# Altium schematic sheet DSP.SchDoc
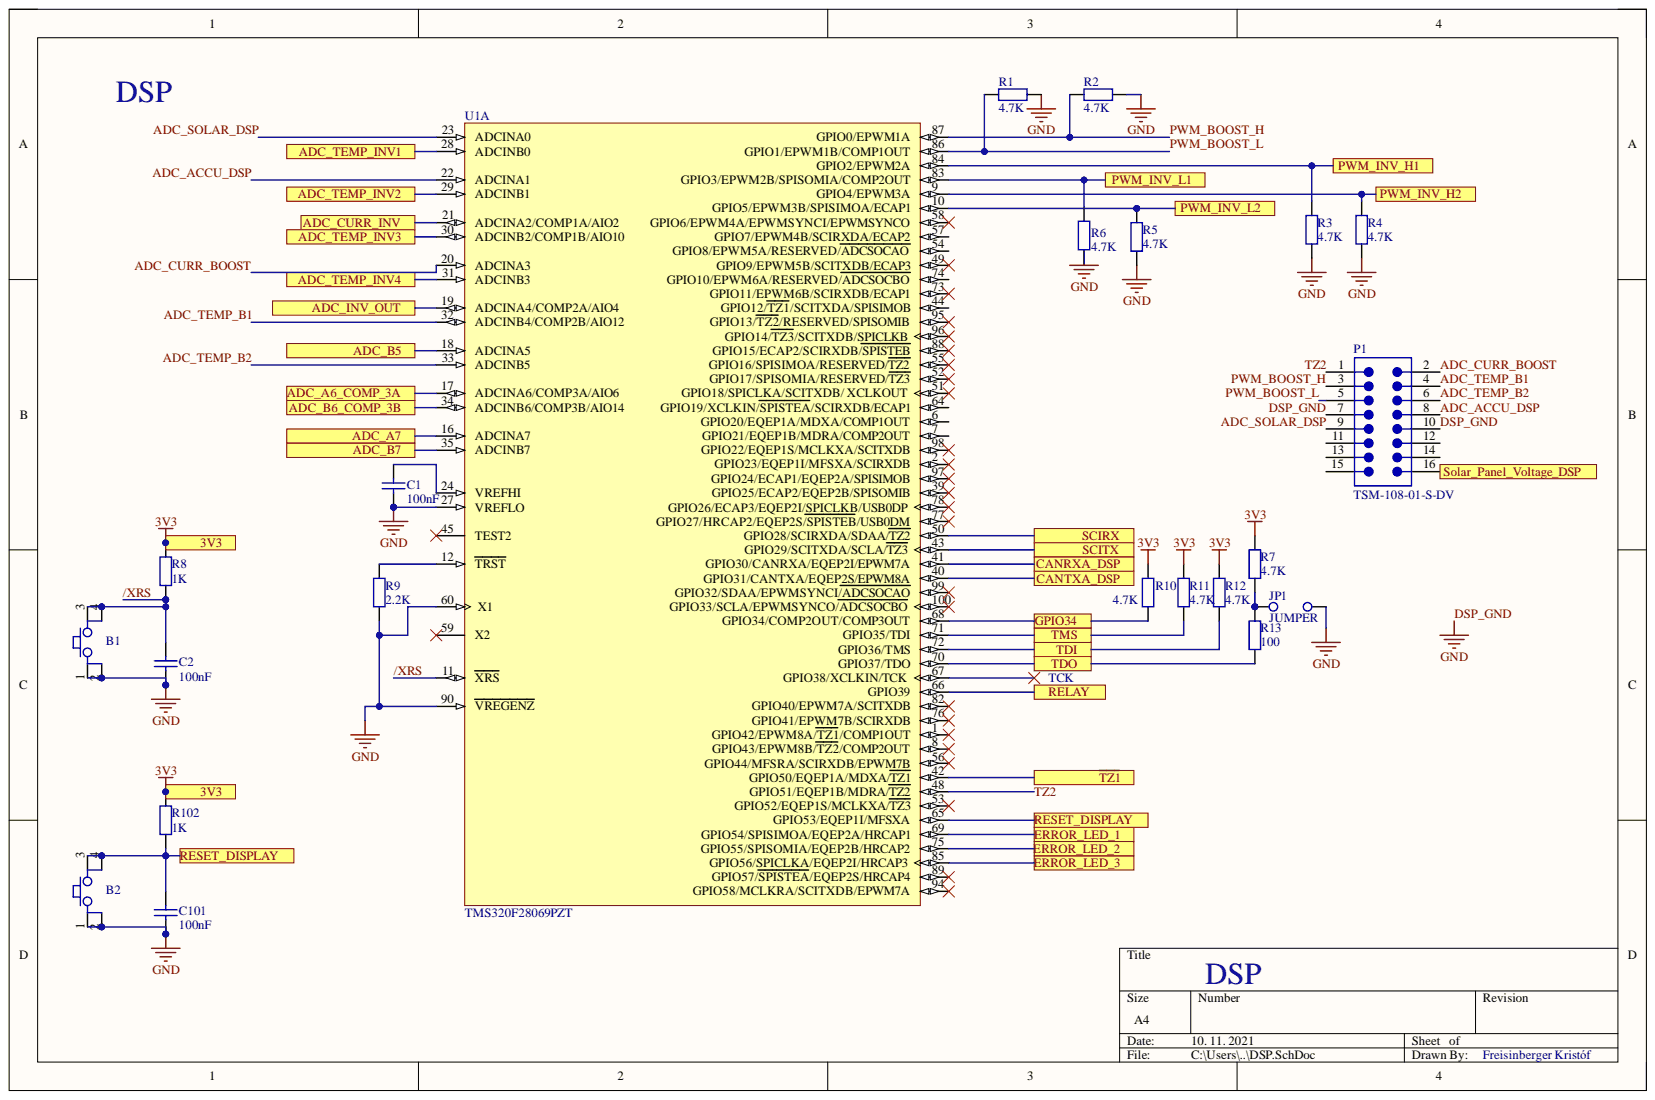
[diagram p0: DSP.SchDoc]

## Parts
B1  Button  [FP_BTN_SMD]  (value not drawn)
B2  Button  [FP_BTN_SMD]  (value not drawn)
C1  100nF  [FP_0805_CAP_085]
C2  100nF  [FP_0805_CAP_085]
C101  100nF  [FP_0805_CAP_085]
JP1  JUMPER  [FP_CONN2_TUSKE_254MM]
P1  TSM-108-01-S-DV  [SMTC-TSM-108-01-X-DV]
R1  4.7K  [FP_0603_RES]
R2  4.7K  [FP_0603_RES]
R3  4.7K  [FP_0603_RES]
R4  4.7K  [FP_0603_RES]
R5  4.7K  [FP_0603_RES]
R6  4.7K  [FP_0603_RES]
R7  4.7K  [FP_0603_RES]
R8  1K  [FP_0603_RES]
R9  2.2K  [FP_0603_RES]
R10  4.7K  [FP_0603_RES]
R11  4.7K  [FP_0603_RES]
R12  4.7K  [FP_0603_RES]
R13  100  [FP_0603_RES]
R102  1K  [FP_0603_RES]
U1  TMS320F28069PZT  [PZ0100A_N]  (part A)

## Ports
3V3, ADC_A6_COMP_3A, ADC_A7, ADC_B5, ADC_B6_COMP_3B, ADC_B7, ADC_CURR_INV, ADC_INV_OUT, ADC_TEMP_INV1, ADC_TEMP_INV2, ADC_TEMP_INV3, ADC_TEMP_INV4, CANRXA_DSP, CANTXA_DSP, ERROR_LED_1, ERROR_LED_2, ERROR_LED_3, GPIO34, PWM_INV_H1, PWM_INV_H2, PWM_INV_L1, PWM_INV_L2, RELAY, RESET_DISPLAY, SCIRX, SCITX, Solar_Panel_Voltage_DSP, TDI, TDO, TMS, ~{TZ1}

## Nets
3V3: R7.2 R8.2 R10.2 R11.2 R12.2 R102.2 ; port 3V3
/XRS: B1.3 B1.4 C2.2 R8.1 U1.11(XRS)
ADC_A6_COMP_3A: U1.17(ADCINA6/COMP3A/AIO6) ; port ADC_A6_COMP_3A
ADC_A7: U1.16(ADCINA7) ; port ADC_A7
ADC_ACCU_DSP: P1.8 U1.22(ADCINA1)
ADC_B5: U1.18(ADCINA5) ; port ADC_B5
ADC_B6_COMP_3B: U1.34(ADCINB6/COMP3B/AIO14) ; port ADC_B6_COMP_3B
ADC_B7: U1.35(ADCINB7) ; port ADC_B7
ADC_CURR_BOOST: P1.2 U1.20(ADCINA3)
ADC_CURR_INV: U1.21(ADCINA2/COMP1A/AIO2) ; port ADC_CURR_INV
ADC_INV_OUT: U1.19(ADCINA4/COMP2A/AIO4) ; port ADC_INV_OUT
ADC_SOLAR_DSP: P1.9 U1.23(ADCINA0)
ADC_TEMP_B1: P1.4 U1.32(ADCINB4/COMP2B/AIO12)
ADC_TEMP_B2: P1.6 U1.33(ADCINB5)
ADC_TEMP_INV1: U1.28(ADCINB0) ; port ADC_TEMP_INV1
ADC_TEMP_INV2: U1.29(ADCINB1) ; port ADC_TEMP_INV2
ADC_TEMP_INV3: U1.30(ADCINB2/COMP1B/AIO10) ; port ADC_TEMP_INV3
ADC_TEMP_INV4: U1.31(ADCINB3) ; port ADC_TEMP_INV4
CANRXA_DSP: U1.41(GPIO30/CANRXA/EQEP2I/EPWM7A) ; port CANRXA_DSP
CANTXA_DSP: U1.40(GPIO31/CANTXA/EQEP2S/EPWM8A) ; port CANTXA_DSP
DSP_GND: B1.1 B1.2 B2.1 B2.2 C1.1 C2.1 C101.1 JP1.2 P1.7 P1.10 R1.2 R2.1 R3.1 R4.1 R5.1 R6.1 R9.1 U1.27(VREFLO) U1.60(X1) U1.90(VREGENZ)
ERROR_LED_1: U1.69(GPIO54/SPISIMOA/EQEP2A/HRCAP1) ; port ERROR_LED_1
ERROR_LED_2: U1.75(GPIO55/SPISOMIA/EQEP2B/HRCAP2) ; port ERROR_LED_2
ERROR_LED_3: U1.85(GPIO56/SPICLKA/EQEP2I/HRCAP3) ; port ERROR_LED_3
GPIO34: R10.1 U1.68(GPIO34/COMP2OUT/COMP3OUT) ; port GPIO34
PWM_BOOST_H: P1.3 R2.2 U1.87(GPIO0/EPWM1A)
PWM_BOOST_L: P1.5 R1.1 U1.86(GPIO1/EPWM1B/COMP1OUT)
PWM_INV_H1: R3.2 U1.84(GPIO2/EPWM2A) ; port PWM_INV_H1
PWM_INV_H2: R4.2 U1.9(GPIO4/EPWM3A) ; port PWM_INV_H2
PWM_INV_L1: R6.2 U1.83(GPIO3/EPWM2B/SPISOMIA/COMP2OUT) ; port PWM_INV_L1
PWM_INV_L2: R5.2 U1.10(GPIO5/EPWM3B/SPISIMOA/ECAP1) ; port PWM_INV_L2
RELAY: U1.66(GPIO39) ; port RELAY
RESET_DISPLAY: B2.3 B2.4 C101.2 R102.1 U1.65(GPIO53/EQEP1I/MFSXA) ; port RESET_DISPLAY
SCIRX: U1.50(GPIO28/SCIRXDA/SDAA/TZ2) ; port SCIRX
SCITX: U1.43(GPIO29/SCITXDA/SCLA/TZ3) ; port SCITX
Solar_Panel_Voltage_DSP: P1.16 ; port Solar_Panel_Voltage_DSP
~{TZ1}: U1.42(GPIO50/EQEP1A/MDXA/TZ1) ; port ~{TZ1}
TDI: R12.1 U1.72(GPIO36/TMS) ; port TDI
TDO: R13.1 U1.70(GPIO37/TDO) ; port TDO
TMS: R11.1 U1.71(GPIO35/TDI) ; port TMS
TZ2: P1.1 U1.48(GPIO51/EQEP1B/MDRA/TZ2)
NetC1_2: C1.2 U1.24(VREFHI)
NetJP1_1: JP1.1 R7.1 R13.2
NetR9_2: R9.2 U1.12(TRST)
NetU1_67: U1.67(GPIO38/XCLKIN/TCK)

Not connected: P1 11 12 13 14 15; U1 1(GPIO42/EPWM8A/TZ1/COMP1OUT) 2(GPIO23/EQEP1I/MFSXA/SCIRXDB) 6(GPIO20/EQEP1A/MDXA/COMP1OUT) 7(GPIO21/EQEP1B/MDRA/COMP2OUT) 8(GPIO43/EPWM8B/TZ2/COMP2OUT) 39(GPIO25/ECAP2/EQEP2B/SPISOMIB) 44(GPIO12/TZ1/SCITXDA/SPISIMOB) 45(TEST2) 49(GPIO9/EPWM5B/SCITXDB/ECAP3) 51(GPIO18/SPICLKA/SCITXDB/_XCLKOUT) 52(GPIO17/SPISOMIA/RESERVED/TZ3) 53(GPIO52/EQEP1S/MCLKXA/TZ3) 54(GPIO8/EPWM5A/RESERVED/ADCSOCAO) 55(GPIO16/SPISIMOA/RESERVED/TZ2) 56(GPIO44/MFSRA/SCIRXDB/EPWM7B) 57(GPIO7/EPWM4B/SCIRXDA/ECAP2) 58(GPIO6/EPWM4A/EPWMSYNCI/EPWMSYNCO) 59(X2) 64(GPIO19/XCLKIN/SPISTEA/SCIRXDB/ECAP1) 73(GPIO11/EPWM6B/SCIRXDB/ECAP1) 74(GPIO10/EPWM6A/RESERVED/ADCSOCBO) 76(GPIO41/EPWM7B/SCIRXDB) 77(GPIO27/HRCAP2/EQEP2S/SPISTEB/USB0DM) 78(GPIO26/ECAP3/EQEP2I/SPICLKB/USB0DP) 82(GPIO40/EPWM7A/SCITXDB) 88(GPIO15/ECAP2/SCIRXDB/SPISTEB) 89(GPIO57/SPISTEA/EQEP2S/HRCAP4) 94(GPIO58/MCLKRA/SCITXDB/EPWM7A) 95(GPIO13/TZ2/RESERVED/SPISOMIB) 96(GPIO14/TZ3/SCITXDB/SPICLKB) 97(GPIO24/ECAP1/EQEP2A/SPISIMOB) 98(GPIO22/EQEP1S/MCLKXA/SCITXDB) 99(GPIO32/SDAA/EPWMSYNCI/ADCSOCAO) 100(GPIO33/SCLA/EPWMSYNCO/ADCSOCBO)

## Library data of the parts
B1 B2 = Button 4P: Push button; Component Kind: Standard; Library Name: Napelem_Inverter.SchLib
C1 C2 C101 = CAP: Capacitor; Component Kind: Standard; Value: 100nF
  C2 C101: Library Name: DCDC_Converter_4_Channel.SchLib
  C1: Library Name: hallglib.SchLib
JP1 = JUMPER: Jumper Wire; Component Kind: Standard; Library Name: hallglib.SchLib
P1 = CMP-1024-00270-1: 0.025" SQ Post Header, Surface Mount, Vertical, -55 to 125 degC, 2.54 mm Pitch, 16-Pin, Male, RoHS; Manufacturer: Samtec; Pitch: 2.54 mm; Component Kind: Standard; ComponentLink1Description: Manufacturer URL; ComponentLink1URL: http://www.samtec.com; ComponentLink2Description: Datasheet; ComponentLink2URL: http://www.samtec.com/documents/webfiles/pdf/TSM.PDF; ComponentLink3Description: Package Specification; ComponentLink3URL: http://www.samtec.com/documents/webfiles/cpdf/TSM-1XX-XX-XXX-XX-X-XXX-X-MKT.pdf; DatasheetVersion: F-214; Library Name: Altium Content Vault; Mounting Technology: Surface Mount; Orientation: Vertical; PackageDescription: Double Row Gullwing ASM; PackageReference: TSM-108-01-X-DV; PackageVersion: DJ, 4/1991; PartNumber: TSM-108-01-S-DV; RoHS: True; Temperature Range: -55 to 125 degC
R1-R13 R102 = RES: Resistor; Component Kind: Standard; Library Name: DCDC_Converter_4_Channel.SchLib
  R1-R7 R10-R12: Value: 4.7K
  R8 R102: Value: 1K
  R9: Value: 2.2K
  R13: Value: 100
U1 = DSP_100_CMP-0546-00052-3: Piccolo Microcontroller, High-Efficiency 32-Bit CPU, 100 KB, 90 MHz, FPU, VCU, 19 Ch PWM, 54 GPIO, 3.3 V, -40 to 105 degC, 100-Pin LQFP (PZ), Green (RoHS & no Sb/Br); Manufacturer: Texas Instruments; 12-bit A/D (#Channels): 16; CAP/QEP: 7/2; CLA: 1; CPU: C28x; FPU: Yes; Frequency (MHz): 90; GPIO: 54; IO Supply (V): 3.3; PWM (Ch): 19; RAM (KB): 100; Timers: 3 32-Bit GP 1 WD; USB: 0; Component Kind: Standard; ComponentLink1Description: Manufacturer URL; ComponentLink1URL: http://www.ti.com/; ComponentLink2Description: Datasheet; ComponentLink2URL: http://www.ti.com/lit/ds/symlink/tms320f28069.pdf; ComponentLink3Description: Package Specification; ComponentLink3URL: http://www.ti.com/lit/ds/symlink/tms320f28066.pdf; DatasheetVersion: SPRS698D; Eco Plan: Green (RoHS & no Sb/Br); Library Name: DCDC_Converter_4_Channel.SchLib; Mounting Technology: Surface Mount; Operating Temperature Range (degC): -40 to 105; PackageDescription: 100-Pin Plastic Quad Flat Package, Body 14 x 14 mm, Pitch 0.5 mm; PackageReference: PZ0100A; PackageVersion: 4040149/B; PartNumber: TMS320F28069PZT; Peak MMACS: 90; VCU: Yes
